# Altium schematic sheet SKD26502-TAH.SchDoc
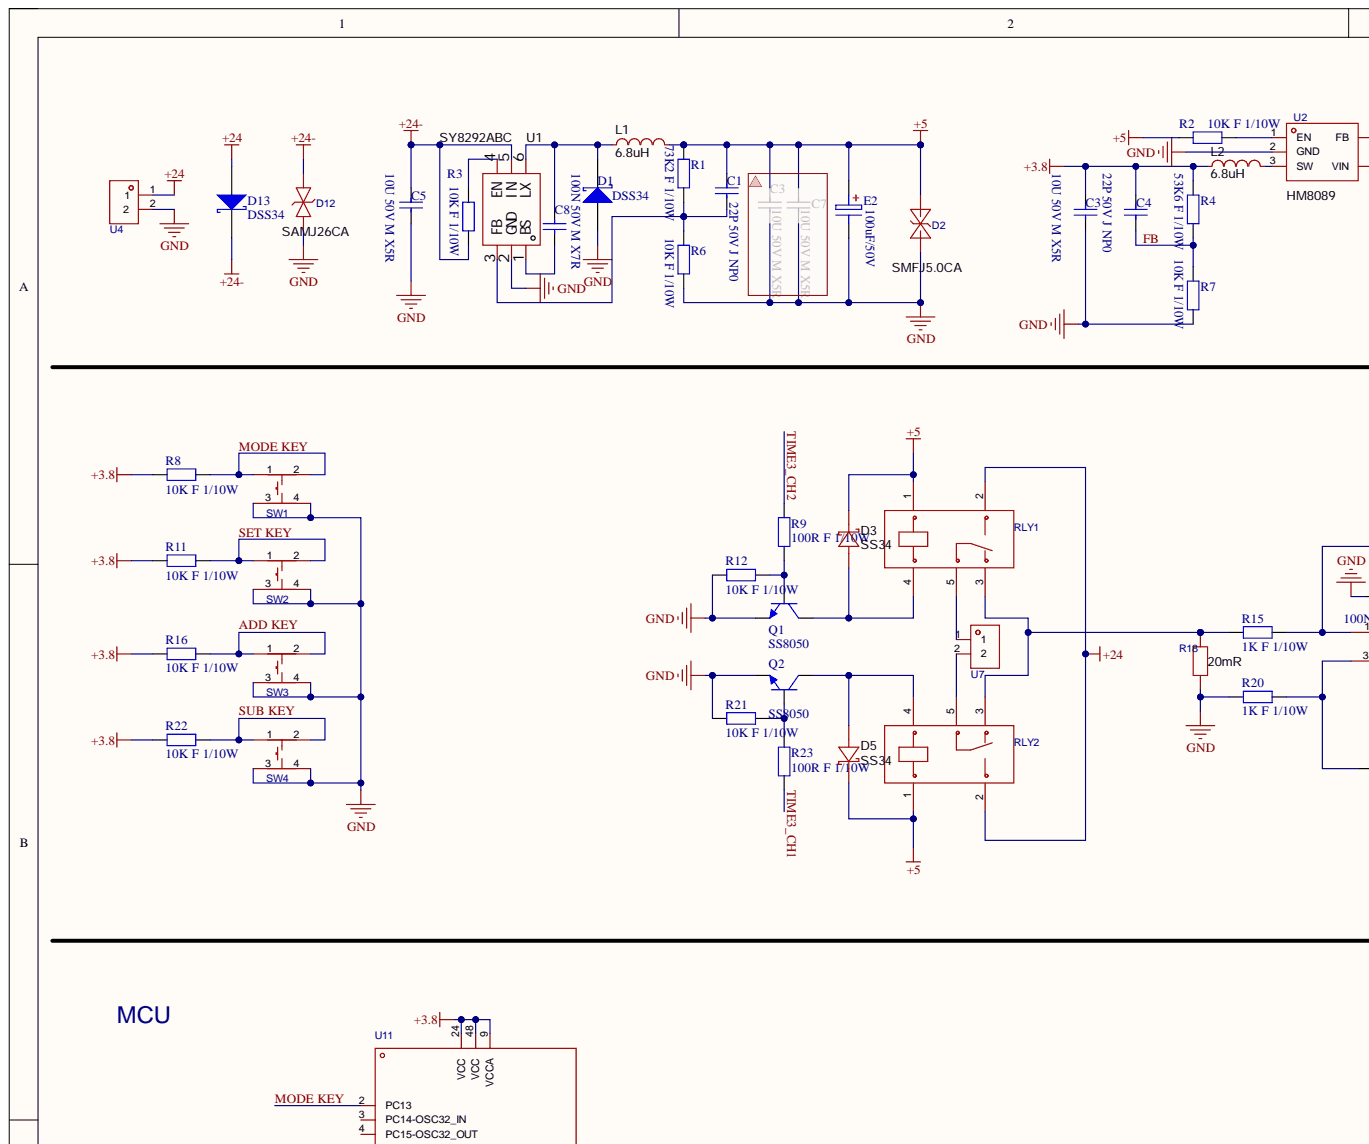
[diagram p0: SKD26502-TAH.SchDoc, top left]
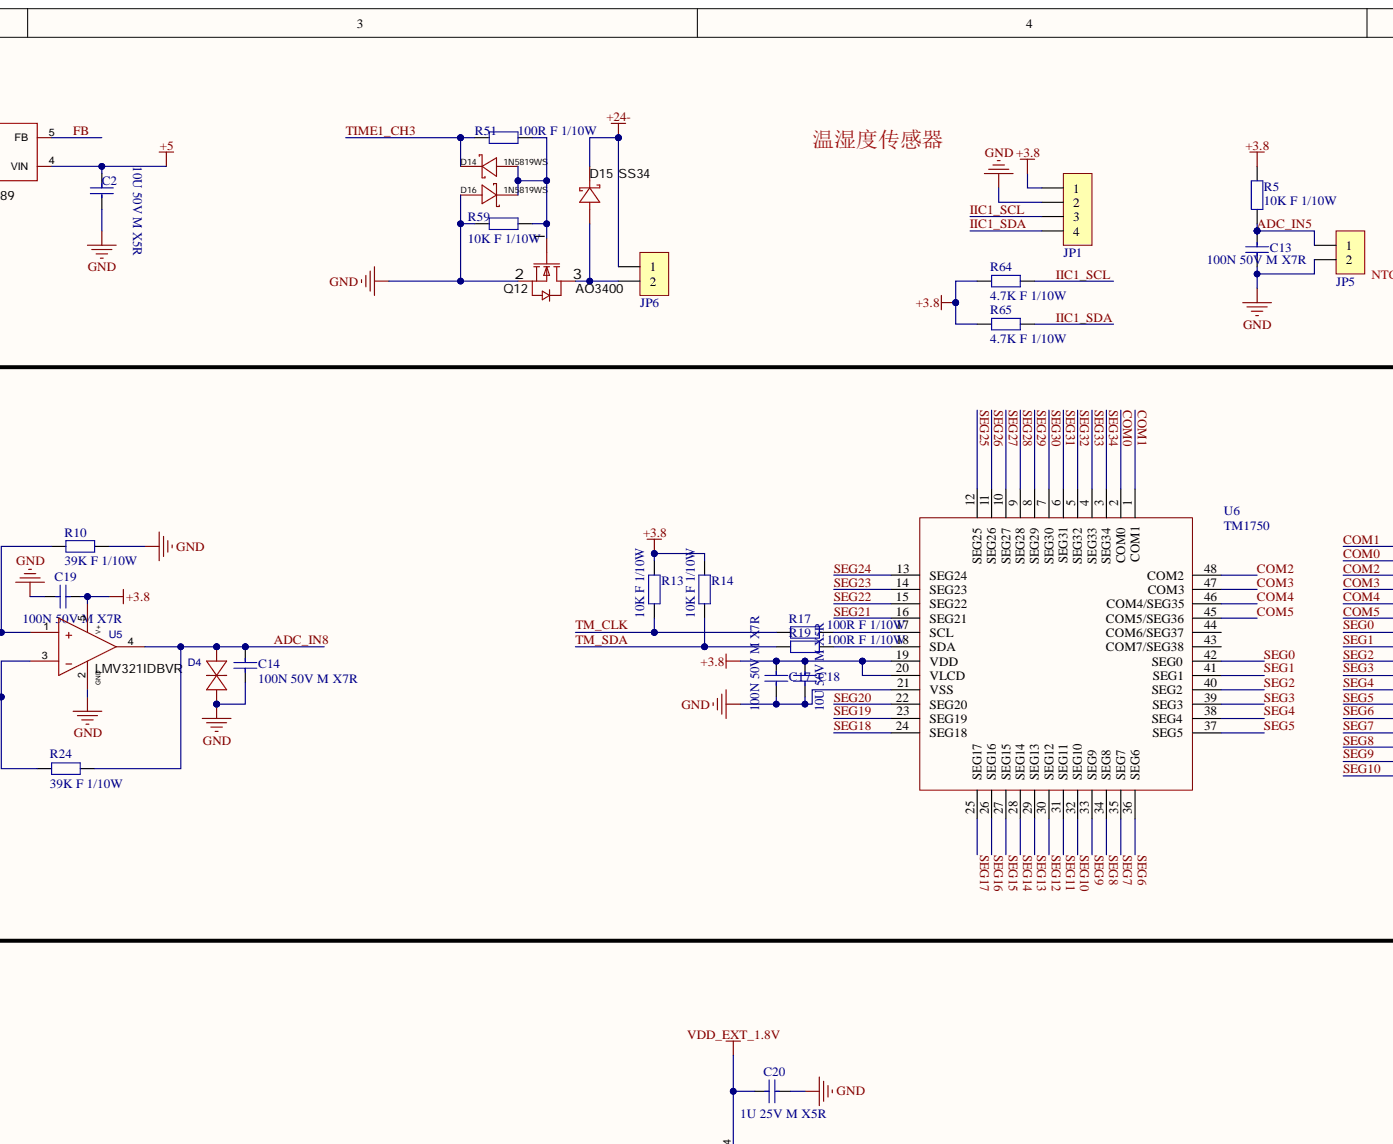
[diagram p1: SKD26502-TAH.SchDoc, top centre]
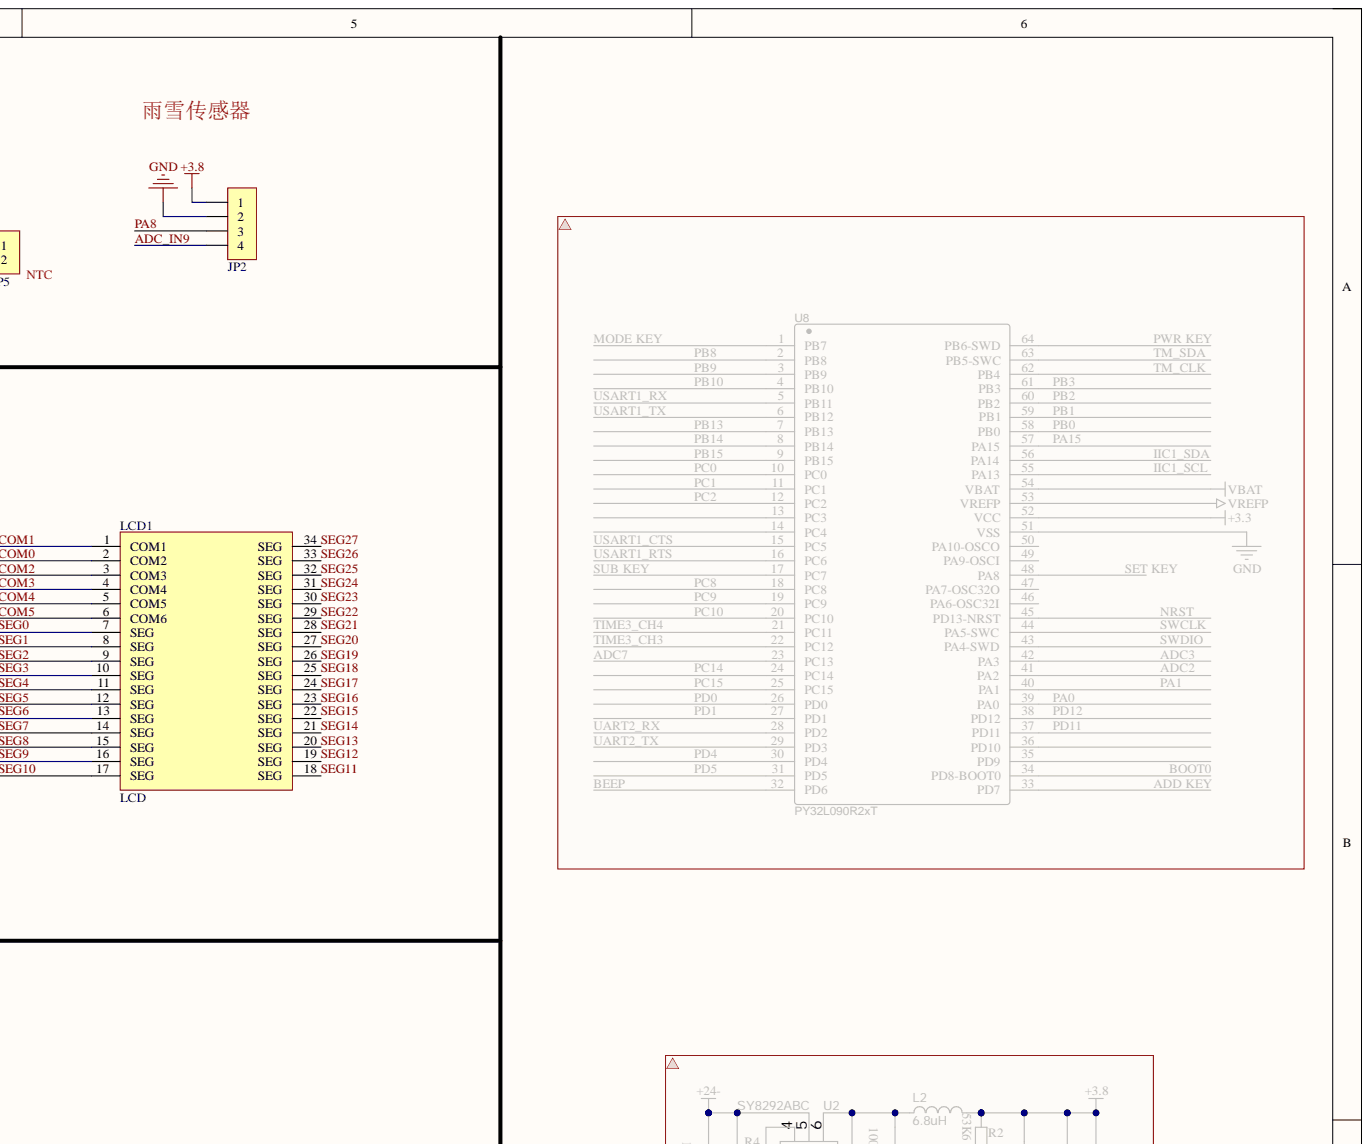
[diagram p2: SKD26502-TAH.SchDoc, top right]
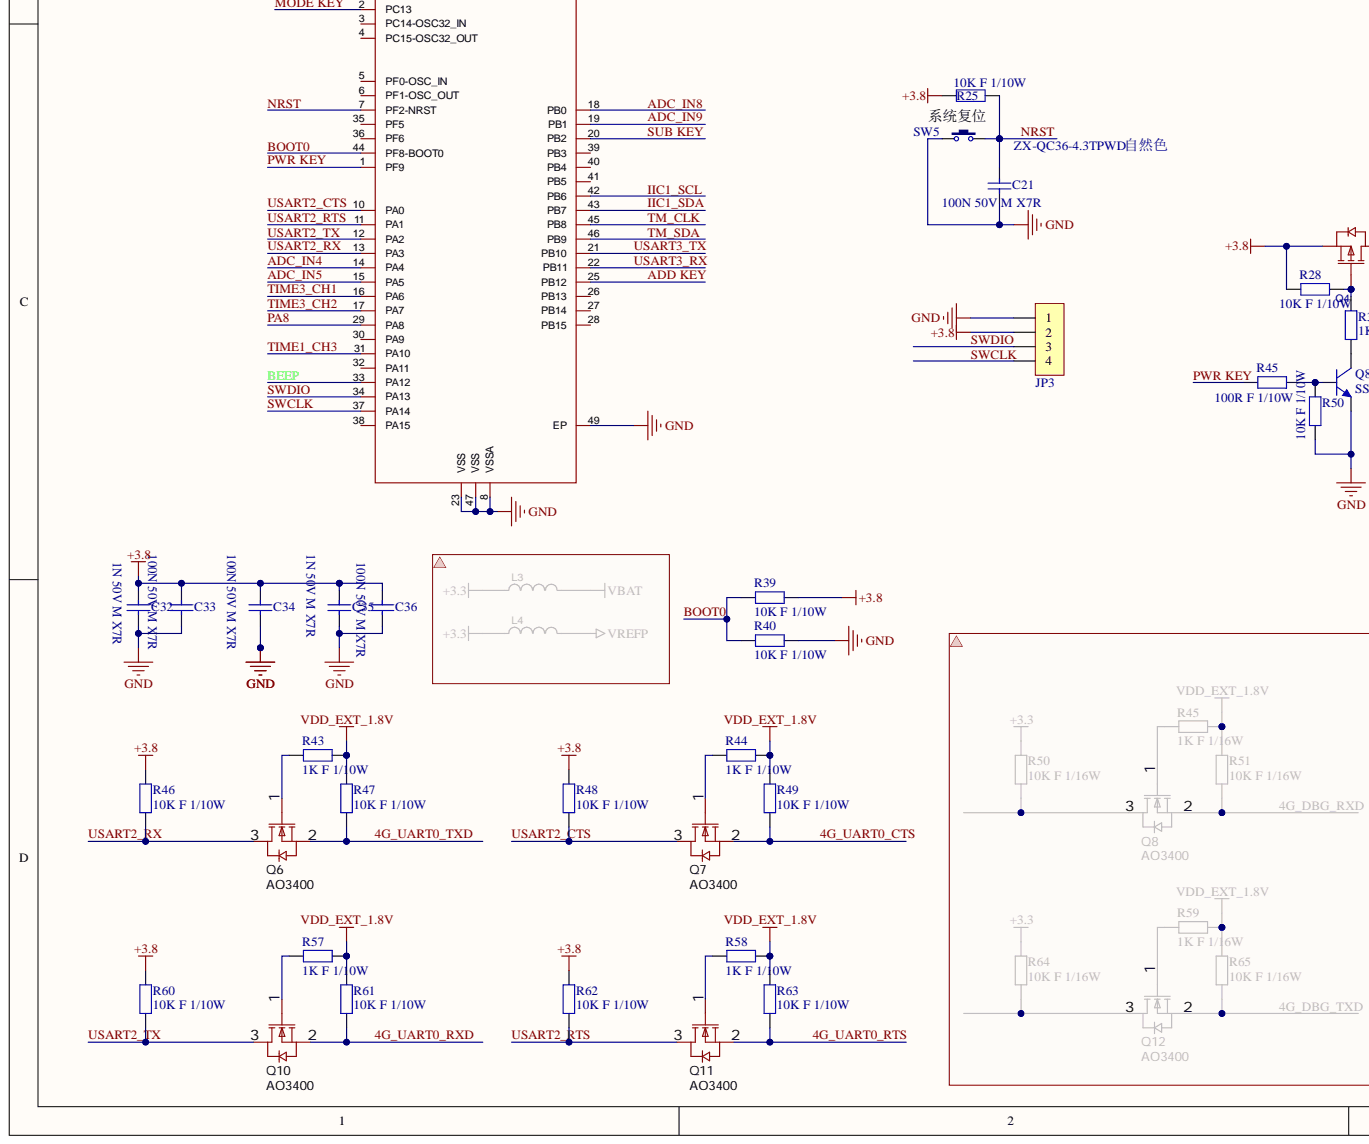
[diagram p3: SKD26502-TAH.SchDoc, bottom left]
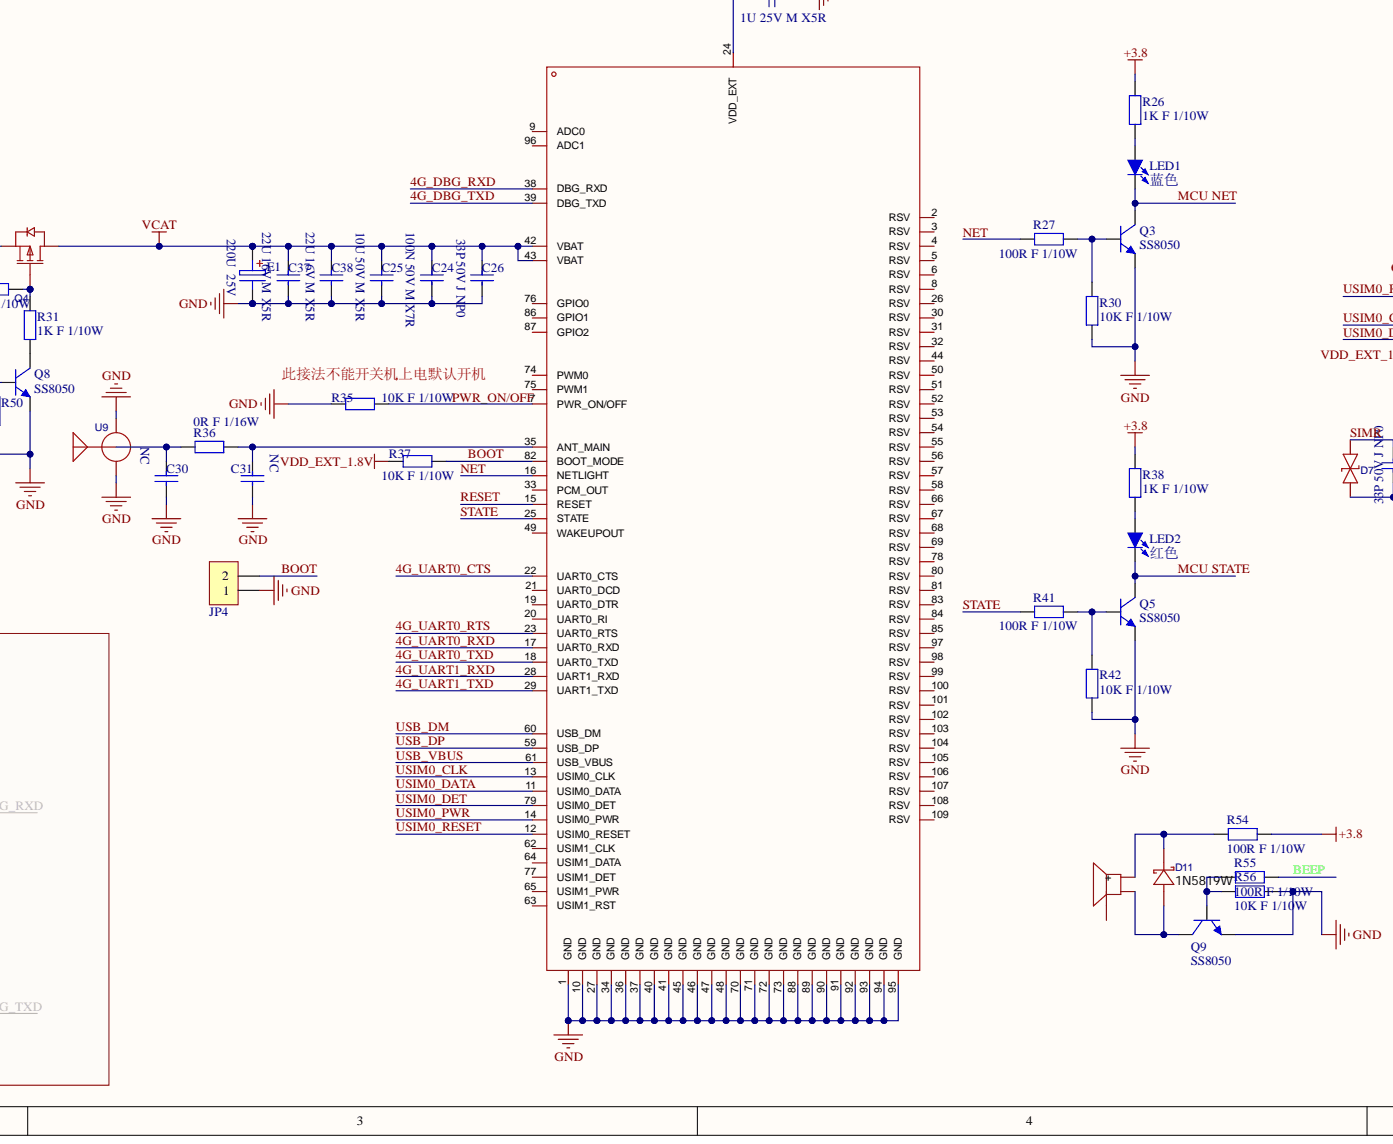
[diagram p4: SKD26502-TAH.SchDoc, bottom centre]
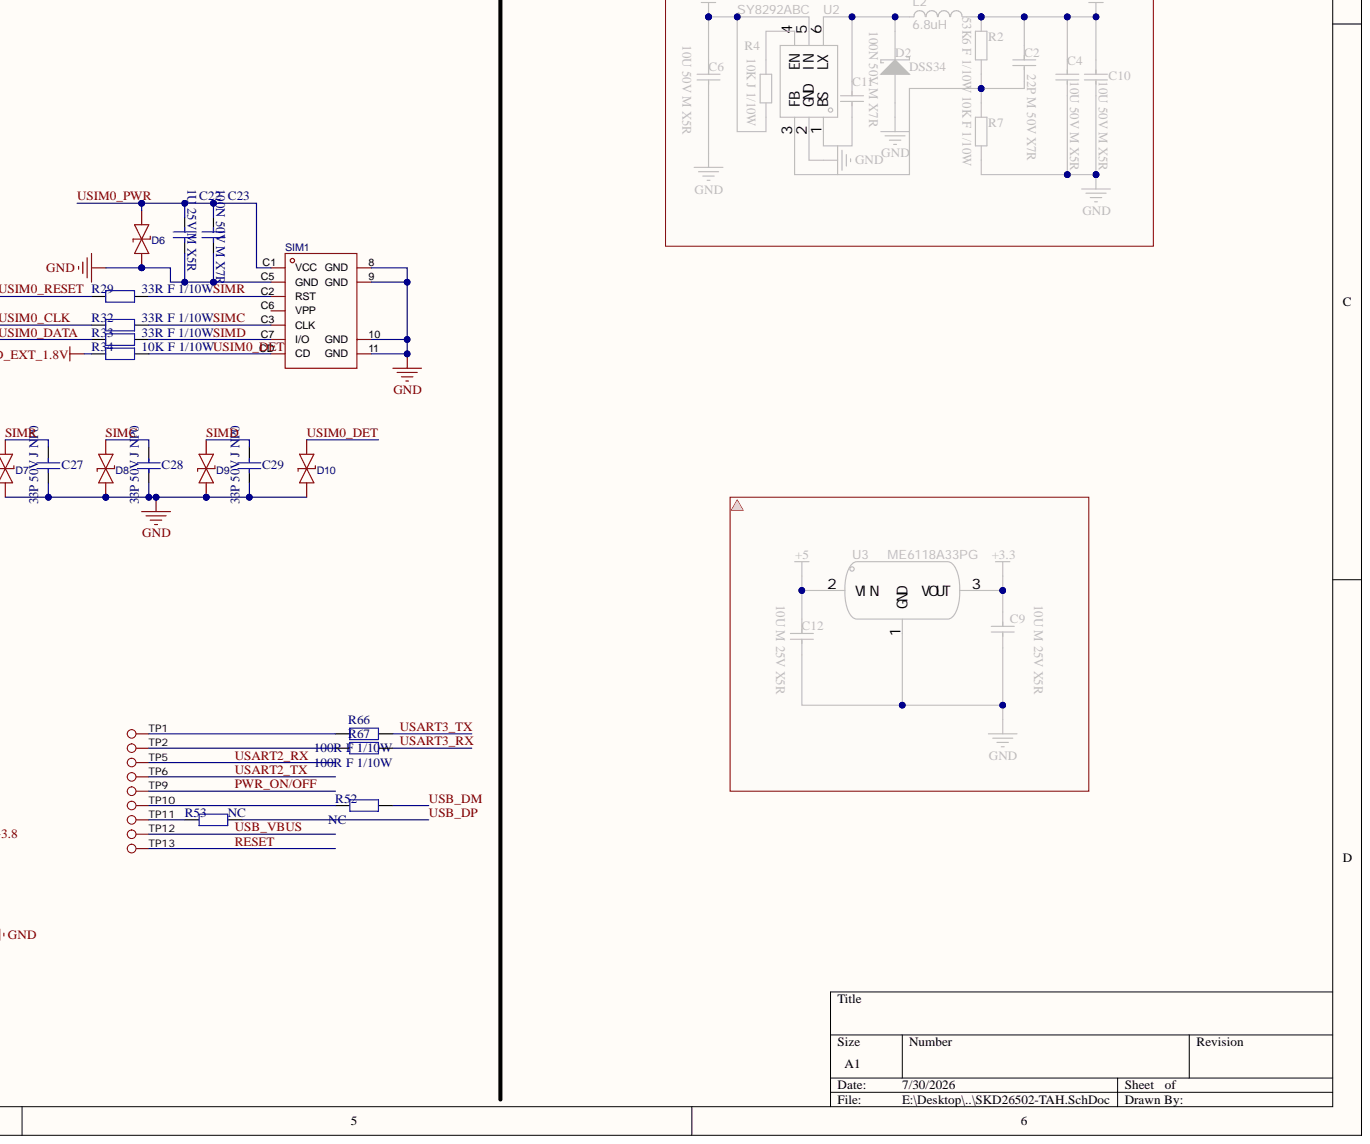
[diagram p5: SKD26502-TAH.SchDoc, bottom right]

## Parts
BUZZER1  smd5020-zk  [BUZ-SMD]  (value not drawn)
C1  22P 50V J NP0  [C 0603_L]
C2  10U 50V M X5R  [C 0603_L]
C3  10U 50V M X5R  [C 0603_L]
C4  22P 50V J NP0  [C 0603_L]
C5  10U 50V M X5R  [C 0603_L]
C8  100N 50V M X7R  [C 0603_L]
C13  100N 50V M X7R  [C 0603_L]
C14  100N 50V M X7R  [C 0603_L]
C17  100N 50V M X7R  [C 0603_L]
C18  10U 50V M X5R  [C 0603_L]
C19  100N 50V M X7R  [C 0603_L]
C20  1U 25V M X5R  [C 0603_L]
C21  100N 50V M X7R  [C 0603_L]
C22  1U 25V M X5R  [C 0603_L]
C23  100N 50V M X7R  [C 0603_L]
C24  100N 50V M X7R  [C 0603_L]
C25  10U 50V M X5R  [C 0603_L]
C26  33P 50V J NP0  [C 0603_L]
C27  33P 50V J NP0  [C 0603_L]
C28  33P 50V J NP0  [C 0603_L]
C29  33P 50V J NP0  [C 0603_L]
C30  NC  [C 0603_L]
C31  NC  [C 0603_L]
C32  1N 50V M X7R  [C 0603_L]
C33  100N 50V M X7R  [C 0603_L]
C34  100N 50V M X7R  [C 0603_L]
C35  1N 50V M X7R  [C 0603_L]
C36  100N 50V M X7R  [C 0603_L]
C37  22U 16V M X5R  [C 0805_L]
C38  22U 16V M X5R  [C 0805_L]
D1  DSS34  [SMA]
D2  SMFJ5.0CA  [SMF]
D3  SS34  [SMA]
D4  SMAJ3.3CA_C19077557  [SMF]  (value not drawn)
D5  SS34  [SMA]
D6  h3v3hd3b  [SOD-323-]  (value not drawn)
D7  h3v3hd3b  [SOD-323-]  (value not drawn)
D8  h3v3hd3b  [SOD-323-]  (value not drawn)
D9  h3v3hd3b  [SOD-323-]  (value not drawn)
D10  h3v3hd3b  [SOD-323-]  (value not drawn)
D11  1N5819W  [SOD-123]
D12  SAMJ26CA  [SMA TVS]
D13  DSS34  [SMA]
D14  1N5819WS  [SOD-323]
D15  SS34  [SMA]
D16  1N5819WS  [SOD-323]
E1  220U  25V  [CM D(6.3*5.4)]
E2  100uF/50V  [CM D(6.3*7.7)]
JP1  HDR-1X4  [PH2.0-LI-4P]  (value not drawn)
JP2  HDR-1X4  [PH2.0-LI-4P]  (value not drawn)
JP3  HDR-1X4  [HDR2.54-LI-4P]  (value not drawn)
JP4  HDR-1X2  [HDR2.54-LI-2P]  (value not drawn)
JP5  HDR-1X2  [PH2.0-LI-2P]  (value not drawn)
JP6  HDR-1X2  [PH2.0-LI-2P]  (value not drawn)
L1  6.8uH  [CD42]
L2  6.8uH  [CD42]
LCD1  LCD  [LCD]
LED1  蓝色  [LED 0603B]
LED2  红色  [LED 0603B]
Q1  SS8050  [SOT23-3N]
Q2  SS8050  [SOT23-3N]
Q3  SS8050  [SOT23-3N]
Q4  ao3401a_c15127  [SOT23-3N]  (value not drawn)
Q5  SS8050  [SOT23-3N]
Q6  AO3400  [SOT23-3N]
Q7  AO3400  [SOT23-3N]
Q8  SS8050  [SOT23-3N]
Q9  SS8050  [SOT23-3N]
Q10  AO3400  [SOT23-3N]
Q11  AO3400  [SOT23-3N]
Q12  AO3400  [SOT23-3N]
R1  73K2 F 1/10W  [R 0603_L]
R2  10K F 1/10W  [R 0603_L]
R3  10K F 1/10W  [R 0603_L]
R4  53K6 F 1/10W  [R 0603_L]
R5  10K F 1/10W  [R 0603_L]
R6  10K F 1/10W  [R 0603_L]
R7  10K F 1/10W  [R 0603_L]
R8  10K F 1/10W  [R 0603_L]
R9  100R F 1/10W  [R 0603_L]
R10  39K F 1/10W  [R 0603_L]
R11  10K F 1/10W  [R 0603_L]
R12  10K F 1/10W  [R 0603_L]
R13  10K F 1/10W  [R 0603_L]
R14  10K F 1/10W  [R 0603_L]
R15  1K F 1/10W  [R 0603_L]
R16  10K F 1/10W  [R 0603_L]
R17  100R F 1/10W  [R 0603_L]
R18  20mR  [R2512]
R19  100R F 1/10W  [R 0603_L]
R20  1K F 1/10W  [R 0603_L]
R21  10K F 1/10W  [R 0603_L]
R22  10K F 1/10W  [R 0603_L]
R23  100R F 1/10W  [R 0603_L]
R24  39K F 1/10W  [R 0603_L]
R25  10K F 1/10W  [R 0603_L]
R26  1K F 1/10W  [R 0603_L]
R27  100R F 1/10W  [R 0603_L]
R28  10K F 1/10W  [R 0603_L]
R29  33R F 1/10W  [R 0603_L]
R30  10K F 1/10W  [R 0603_L]
R31  1K F 1/10W  [R 0603_L]
R32  33R F 1/10W  [R 0603_L]
R33  33R F 1/10W  [R 0603_L]
R34  10K F 1/10W  [R 0603_L]
R35  10K F 1/10W  [R 0603_L]
R36  0R F 1/16W  [R 0603_L]
R37  10K F 1/10W  [R 0603_L]
R38  1K F 1/10W  [R 0603_L]
R39  10K F 1/10W  [R 0603_L]
R40  10K F 1/10W  [R 0603_L]
R41  100R F 1/10W  [R 0603_L]
R42  10K F 1/10W  [R 0603_L]
R43  1K F 1/10W  [R 0603_L]
R44  1K F 1/10W  [R 0603_L]
R45  100R F 1/10W  [R 0603_L]
R46  10K F 1/10W  [R 0603_L]
R47  10K F 1/10W  [R 0603_L]
R48  10K F 1/10W  [R 0603_L]
R49  10K F 1/10W  [R 0603_L]
R50  10K F 1/10W  [R 0603_L]
R51  100R F 1/10W  [R 0603_L]
R52  NC  [R 0402_L]
R53  NC  [R 0402_L]
R54  100R F 1/10W  [R 0603_L]
R55  100R F 1/10W  [R 0603_L]
R56  10K F 1/10W  [R 0603_L]
R57  1K F 1/10W  [R 0603_L]
R58  1K F 1/10W  [R 0603_L]
R59  10K F 1/10W  [R 0603_L]
R60  10K F 1/10W  [R 0603_L]
R61  10K F 1/10W  [R 0603_L]
R62  10K F 1/10W  [R 0603_L]
R63  10K F 1/10W  [R 0603_L]
R64  4.7K F 1/10W  [R 0603_L]
R65  4.7K F 1/10W  [R 0603_L]
R66  100R F 1/10W  [R 0603_L]
R67  100R F 1/10W  [R 0603_L]
RLY1  srd-05vdc-sl-c  [RELAY-TH]  (value not drawn)
RLY2  srd-05vdc-sl-c  [RELAY-TH]  (value not drawn)
SIM1  nano sim 7p h1.37  [SIM_SMD_NANO]  (value not drawn)
SW1  zx-qc66-8.5tp  [SMD_4P]  (value not drawn)
SW2  zx-qc66-8.5tp  [SMD_4P]  (value not drawn)
SW3  zx-qc66-8.5tp  [SMD_4P]  (value not drawn)
SW4  zx-qc66-8.5tp  [SMD_4P]  (value not drawn)
SW5  ZX-QC36-4.3TPWD自然色  [TSW SMD-3*6*2.5]
TP1  (no value)  [1.0TESTPOINT]
TP2  (no value)  [1.0TESTPOINT]
TP5  (no value)  [1.0TESTPOINT]
TP6  (no value)  [1.0TESTPOINT]
TP9  (no value)  [1.0TESTPOINT]
TP10  (no value)  [1.0TESTPOINT]
TP11  (no value)  [1.0TESTPOINT]
TP12  (no value)  [1.0TESTPOINT]
TP13  (no value)  [1.0TESTPOINT]
U1  SY8292ABC  [SOT23-6N]
U2  HM8089  [SOT-23-5-]
U4  kf7620-7.62-2p  [7.62*2P]  (value not drawn)
U5  LMV321IDBVR  [SOT23-5]
U6  TM1750  [LQFP48-7*7]
U7  kf7620-7.62-2p  [7.62*2P]  (value not drawn)
U9  ipex1-txz 142  [ANT-SMD]  (value not drawn)
U10  ml307c-dl  [LCC-LGA-109_L17.7-W15.8-P1.10_MC661]  (value not drawn)
U11  py32f071c1bu6tr  [QFN-48]  (value not drawn)

## Nets
+3.8: C3.2 C4.2 C17.2 C18.2 C19.2 C32.2 C33.2 C34.2 C35.2 C36.2 JP1.1 JP2.1 JP3.2 L2.1 Q4.2 R4.2 R5.2 R8.1 R11.1 R13.2 R14.2 R16.1 R22.1 R25.2 R26.2 R28.1 R38.2 R39.1 R46.2 R48.2 R54.2 R60.2 R62.2 R64.2 R65.2 U5.5 U6.19(VDD) U6.20(VLCD) U11.9 U11.24(VCC) U11.48(VCC)
+5: C1.2 C2.2 D2.2 D3.2 D5.2 E2.1 L1.2 R1.2 R2.2 RLY1.1 RLY2.1 U2.4(VIN)
+24: D13.1 RLY1.2 RLY2.2 U4.1
+24-: C5.2 D12.2 D13.2 D15.2 JP6.1 R3.1 U1.5(IN)
GND: C2.1 C3.1 C5.1 C13.2 C14.2 C17.1 C18.1 C19.1 C20.1 C21.2 C22.1 C23.1 C24.1 C25.1 C26.1 C27.2 C28.2 C29.2 C30.1 C31.1 C32.1 C33.1 C34.1 C35.1 C36.1 C37.1 C38.1 D1.1 D2.1 D4.1 D6.1 D7.1 D8.1 D9.1 D10.1 D12.1 D16.2 E1.2 E2.2 JP1.2 JP2.2 JP3.1 JP4.1 JP5.2 Q1.2 Q2.2 Q3.2 Q5.2 Q8.2 Q9.2 Q12.2 R6.1 R7.1 R10.2 R12.2 R18.1 R20.1 R21.2 R30.2 R35.1 R40.1 R42.2 R50.2 R56.1 R59.2 SIM1.8(GND) SIM1.9(GND) SIM1.10(GND) SIM1.11(GND) SIM1.C5(GND) SW1.3 SW1.4 SW2.3 SW2.4 SW3.3 SW3.4 SW4.3 SW4.4 SW5.1 U1.2(GND) U2.2(GND) U4.2 U5.2 U6.21(VSS) U9.2 U9.3 U10.1(GND) U10.10(GND) U10.27(GND) U10.34(GND) U10.36(GND) U10.37(GND) U10.40(GND) U10.41(GND) U10.45(GND) U10.46(GND) U10.47(GND) U10.48(GND) U10.70(GND) U10.71(GND) U10.72 U10.73 U10.88(GND) U10.89(GND) U10.90(GND) U10.91(GND) U10.92(GND) U10.93(GND) U10.94(GND) U10.95(GND) U11.8 U11.23(VSS) U11.47(VSS) U11.49(EP)
VCAT: C24.2 C25.2 C26.2 C37.2 C38.2 E1.1 Q4.3 U10.42(VBAT) U10.43(VBAT)
VDD_EXT_1.8V: C20.2 R34.1 R37.1 R43.1 R44.1 R47.2 R49.2 R57.1 R58.1 R61.2 R63.2 U10.24(VDD_EXT)
4G_DBG_RXD: U10.38(DBG_RXD)
4G_DBG_TXD: U10.39(DBG_TXD)
4G_UART0_CTS: Q7.2 R49.1 U10.22(UART0_CTS)
4G_UART0_RTS: Q11.2 R63.1 U10.23(UART0_RTS)
4G_UART0_RXD: Q10.2 R61.1 U10.17(UART0_RXD)
4G_UART0_TXD: Q6.2 R47.1 U10.18(UART0_TXD)
4G_UART1_RXD: U10.28(UART1_RXD)
4G_UART1_TXD: U10.29(UART1_TXD)
ADC_IN4: U11.14(PA4)
ADC_IN5: C13.1 JP5.1 R5.1 U11.15(PA5)
ADC_IN8: C14.1 D4.2 R24.2 U5.4 U11.18(PB0)
ADC_IN9: JP2.4 U11.19(PB1)
ADD KEY: R16.2 SW3.1 SW3.2 U11.25(PB12)
BEEP: R55.2 U11.33(PA12)
BOOT: JP4.2 R37.2 U10.82(BOOT_MODE)
BOOT0: R39.2 R40.2 U11.44(PF8-BOOT0)
COM0: LCD1.2(COM2) U6.2(COM0)
COM1: LCD1.1(COM1) U6.1(COM1)
COM2: LCD1.3(COM3) U6.48(COM2)
COM3: LCD1.4(COM4) U6.47(COM3)
COM4: LCD1.5(COM5) U6.46(COM4/SEG35)
COM5: LCD1.6(COM6) U6.45(COM5/SEG36)
FB: C4.1 R4.1 R7.2 U2.5(FB)
IIC1_SCL: JP1.3 R64.1 U11.42(PB6)
IIC1_SDA: JP1.4 R65.1 U11.43(PB7)
MCU NET: LED1.2 Q3.3
MCU STATE: LED2.2 Q5.3
MODE KEY: R8.2 SW1.1 SW1.2 U11.2(PC13)
NET: R27.1 U10.16(NETLIGHT)
NRST: C21.1 R25.1 SW5.2 U11.7(PF2-NRST)
PA8: JP2.3 U11.29(PA8)
PWR KEY: R45.1 U11.1(PF9)
PWR_ON/OFF: R35.2 TP9.1 U10.7(PWR_ON/OFF)
RESET: TP13.1 U10.15(RESET)
SEG0: LCD1.7(SEG) U6.42(SEG0)
SEG1: LCD1.8(SEG) U6.41(SEG1)
SEG2: LCD1.9(SEG) U6.40(SEG2)
SEG3: LCD1.10(SEG) U6.39(SEG3)
SEG4: LCD1.11(SEG) U6.38(SEG4)
SEG5: LCD1.12(SEG) U6.37(SEG5)
SEG6: LCD1.13(SEG) U6.36(SEG6)
SEG7: LCD1.14(SEG) U6.35(SEG7)
SEG8: LCD1.15(SEG) U6.34(SEG8)
SEG9: LCD1.16(SEG) U6.33(SEG9)
SEG10: LCD1.17(SEG) U6.32(SEG10)
SEG11: LCD1.18(SEG) U6.31(SEG11)
SEG12: LCD1.19(SEG) U6.30(SEG12)
SEG13: LCD1.20(SEG) U6.29(SEG13)
SEG14: LCD1.21(SEG) U6.28(SEG14)
SEG15: LCD1.22(SEG) U6.27(SEG15)
SEG16: LCD1.23(SEG) U6.26(SEG16)
SEG17: LCD1.24(SEG) U6.25(SEG17)
SEG18: LCD1.25(SEG) U6.24(SEG18)
SEG19: LCD1.26(SEG) U6.23(SEG19)
SEG20: LCD1.27(SEG) U6.22(SEG20)
SEG21: LCD1.28(SEG) U6.16(SEG21)
SEG22: LCD1.29(SEG) U6.15(SEG22)
SEG23: LCD1.30(SEG) U6.14(SEG23)
SEG24: LCD1.31(SEG) U6.13(SEG24)
SEG25: LCD1.32(SEG) U6.12(SEG25)
SEG26: LCD1.33(SEG) U6.11(SEG26)
SEG27: LCD1.34(SEG) U6.10(SEG27)
SEG28: U6.9(SEG28)
SEG29: U6.8(SEG29)
SEG30: U6.7(SEG30)
SEG31: U6.6(SEG31)
SEG32: U6.5(SEG32)
SEG33: U6.4(SEG33)
SEG34: U6.3(SEG34)
SET KEY: R11.2 SW2.1 SW2.2
SIMC: C28.1 D8.2 R32.2 SIM1.C3(CLK)
SIMD: C29.1 D9.2 R33.2 SIM1.C7(I/O)
SIMR: C27.1 D7.2 R29.2 SIM1.C2(RST)
STATE: R41.1 U10.25(STATE)
SUB KEY: R22.2 SW4.1 SW4.2 U11.20(PB2)
SWCLK: JP3.4 U11.37(PA14)
SWDIO: JP3.3 U11.34(PA13)
TIME1_CH3: D14.1 R51.1 U11.31(PA10)
TIME3_CH1: R23.2 U11.16(PA6)
TIME3_CH2: R9.2 U11.17(PA7)
TM_CLK: R13.1 R17.2 U11.45(PB8)
TM_SDA: R14.1 R19.1 U11.46(PB9)
USART2_CTS: Q7.3 R48.1 U11.10(PA0)
USART2_RTS: Q11.3 R62.1 U11.11(PA1)
USART2_RX: Q6.3 R46.1 TP5.1 U11.13(PA3)
USART2_TX: Q10.3 R60.1 TP6.1 U11.12(PA2)
USART3_RX: R67.2 U11.22(PB11)
USART3_TX: R66.2 U11.21(PB10)
USB_DM: R52.2 U10.60(USB_DM)
USB_DP: R53.2 U10.59(USB_DP)
USB_VBUS: TP12.1 U10.61(USB_VBUS)
USIM0_CLK: R32.1 U10.13(USIM0_CLK)
USIM0_DATA: R33.1 U10.11(USIM0_DATA)
USIM0_DET: D10.2 R34.2 SIM1.CD U10.79(USIM0_DET)
USIM0_PWR: C22.2 C23.2 D6.2 SIM1.C1(VCC) U10.14(USIM0_PWR)
USIM0_RESET: R29.1 U10.12(USIM0_RESET)
NetBUZZER1_1: BUZZER1.1 D11.1 R54.1
NetBUZZER1_2: BUZZER1.2 D11.2 Q9.3
NetC1_1: C1.1 R1.1 R6.2 U1.3(FB)
NetC8_1: C8.1 U1.1(BS)
NetC8_2: C8.2 D1.2 L1.1 U1.6(LX)
NetC30_2: C30.2 R36.1 U9.1
NetC31_2: C31.2 R36.2 U10.35(ANT_MAIN)
NetD3_1: D3.1 Q1.3 RLY1.4
NetD5_1: D5.1 Q2.3 RLY2.4
NetD14_2: D14.2 D16.1 Q12.1 R51.2 R59.1
NetD15_1: D15.1 JP6.2 Q12.3
NetL2_2: L2.2 U2.3(SW)
NetLED1_1: LED1.1 R26.1
NetLED2_1: LED2.1 R38.1
NetQ1_1: Q1.1 R9.1 R12.1
NetQ2_1: Q2.1 R21.1 R23.1
NetQ3_1: Q3.1 R27.2 R30.1
NetQ4_1: Q4.1 R28.2 R31.2
NetQ5_1: Q5.1 R41.2 R42.1
NetQ6_1: Q6.1 R43.2
NetQ7_1: Q7.1 R44.2
NetQ8_1: Q8.1 R45.2 R50.1
NetQ8_3: Q8.3 R31.1
NetQ9_1: Q9.1 R55.1 R56.2
NetQ10_1: Q10.1 R57.2
NetQ11_1: Q11.1 R58.2
NetR2_1: R2.1 U2.1(EN)
NetR3_2: R3.2 U1.4(EN)
NetR10_1: R10.1 R15.2 U5.1
NetR15_1: R15.1 R18.2 RLY1.3 RLY2.3
NetR17_1: R17.1 U6.17(SCL)
NetR19_2: R19.2 U6.18(SDA)
NetR20_2: R20.2 R24.1 U5.3
NetR52_1: R52.1 TP10.1
NetR53_1: R53.1 TP11.1
NetR66_1: R66.1 TP1.1
NetR67_1: R67.1 TP2.1
NetRLY1_5: RLY1.5 U7.1
NetRLY2_5: RLY2.5 U7.2

Not connected: BUZZER1 3; SIM1 C6(VPP); U6 43(COM7/SEG38) 44(COM6/SEG37); U10 2(RSV) 3(RSV) 4(RSV) 5(RSV) 6(RSV) 8(RSV) 9(ADC0) 19(UART0_DTR) 20(UART0_RI) 21(UART0_DCD) 26(RSV) 30(RSV) 31(RSV) 32(RSV) 33(PCM_OUT) 44(RSV) 49(WAKEUPOUT) 50(RSV) 51(RSV) 52(RSV) 53(RSV) 54(RSV) 55(RSV) 56(RSV) 57(RSV) 58(RSV) 62(USIM1_CLK) 63(USIM1_RST) 64(USIM1_DATA) 65(USIM1_PWR) 66(RSV) 67(RSV) 68(RSV) 69(RSV) 74(PWM0) 75(PWM1) 76(GPIO0) 77(USIM1_DET) 78(RSV) 80(RSV) 81(RSV) 83(RSV) 84(RSV) 85(RSV) 86(GPIO1) 87(GPIO2) 96(ADC1) 97(RSV) 98(RSV) 99(RSV) 100(RSV) 101(RSV) 102(RSV) 103(RSV) 104(RSV) 105(RSV) 106(RSV) 107(RSV) 108(RSV) 109(RSV); U11 3(PC14-OSC32_IN) 4(PC15-OSC32_OUT) 5(PF0-OSC_IN) 6(PF1-OSC_OUT) 26(PB13) 27(PB14) 28(PB15) 30(PA9) 32(PA11) 35(PF5) 36(PF6) 38(PA15) 39(PB3) 40(PB4) 41(PB5)

## Library data of the parts
BUZZER1 = smd5020-zk: 驱动方式:无源(外部驱动);构造类型:电磁式;额定电压:3V;频率:4kHz;声压(SPL):78dB;; Manufacturer: XHXDZ(兴华鑫); AddInBom: yes; Current Consumption: 100mA; Sound Pressure Level(SPL): 78dB; Value: 4kHz; Voltage - Supply: 2V~4V; Voltage Rating: 3V; Component Kind: Standard; ConvertToPcb: yes; Datasheet: https://item.szlcsc.com/datasheet/SMD5020-ZK/51674924.html; Driver Circuitry: 无源(外部驱动); FootprintName: BUZ-SMD_3P-SMD5020-ZK; Height: 2mm; JLCPCB Part Class: Extended Part; LCSC Part Name: 蜂鸣器; Length: 5mm; ManufacturerPart: SMD5020-ZK; Supplier: LCSC; Supplier Footprint: SMD,5.3x5.3mm; SupplierPart: C49246955; Symbol: SMD5020-ZK; Technology: 电磁式; Width: 5mm
C1-C5 C8 C13 C14 C17-C38 = C: 无极性贴片电容; 版权归属: 源创客; BOM名称: 无极性贴片电容; Component Kind: Standard; Library Name: 0.1 - 电阻-电容-电感.SchLib; 学习资源: www.ooocke.com
D1 D13 = SS14: 肖特基二极管; 属性: 40V/1A; BOM名称: 肖特基二极管; Component Kind: Standard; Library Name: 0.2 -  二极管-整流桥.SchLib
D2 = smaj5.0ca_c19077524: 极性:双向;反向截止电压(Vrwm):5V;钳位电压:9.2V;峰值脉冲电流(Ipp):43.5A@10/1000us;峰值脉冲功率(Ppp):400W@10/1000us;击穿电压:7V;; Manufacturer: R+O(宏嘉诚); AddInBom: yes; Clamping Voltage: 9.2V; level of protection: IEC 61000-4-2; ModelId: 528a94b6593f4af79cbff3f4c52e0784; ModelName: SMA_L4.3-W2.6-LS5.0-BI; ModelTransform: 198.4248,102.362,0,0,0,0,0,0,0; Number of Channels: 单路; Polarity: 双向; Reverse Leakage Current (Ir): 800uA; Reverse Stand-Off Voltage (Vrwm): 5V; Type: TVS; Voltage - Breakdown: 7V; Component Kind: Standard; ConvertToPcb: yes; Datasheet: https://item.szlcsc.com/datasheet/SMAJ5.0CA/20275609.html; FootprintName: SMA_L4.3-W2.6-LS5.0-BI; JLCPCB Part Class: Extended Part; LCSC Part Name: 双向TVS 5V截止 峰值浪涌电流：43.5A@10/1000us; ManufacturerPart: SMAJ5.0CA; Operating Temperature: -55℃~+150℃; Peak Pulse Current (Ipp): 43.5A@10/1000us; Peak Pulse Power Dissipation (Ppp): 400W@10/1000us; Supplier: LCSC; Supplier Footprint: DO-214AC(SMA); SupplierPart: C19077524; Symbol: SMAJ5.0CA_C19077524
D3 D5 D15 = SS34_C7420365: Manufacturer: 宏迦橙; Add into BOM: yes; Average Rectified Current (Io): 3A; Diode Configuration: 独立式; Forward Voltage (Vf@If): 550mV@3.0A; Peak forward surge current: 80A; Reverse Leakage Current (Ir): 200uA@40V; Reverse Voltage (Vr): 40V; Component Kind: Standard; Convert to PCB: yes; Datasheet: https://atta.szlcsc.com/upload/public/pdf/source/20230828/75438452AEE6C276EDA92B2AF599BE05.pdf; Device: SS34_C7420365; JLCPCB Part Class: Extended Part; LCSC Part Name: 电压:40V 电流:3A; Manufacturer Part: SS34; PCB3D: SMA_L4.3-W2.6-LS5.0-FD; Supplier: LCSC; Supplier Footprint: SMA(DO-214AC); Supplier Part: C7420365; Symbol: SS34_C7420365
D4 = SMBJ3.3CA_C19077557: Component Kind: Standard; Symbol: SMBJ3.3CA_C19077557
D6-D10 = h3v3hd3b: 极性:双向;反向截止电压(Vrwm):3.3V;钳位电压:17V;峰值脉冲电流(Ipp):25A@8/20us;峰值脉冲功率(Ppp):375W@8/20us;击穿电压:8V;; Manufacturer: R+O(宏嘉诚); AddInBom: yes; Clamping Voltage: 17V; Junction Capacitance: 100pF; level of protection: IEC 61000-4-5；IEC 61000-4-2; ModelId: dd567d373db645b3a267ff184369a271; ModelName: SOD-323_L1.7-W1.3-LS2.7-BI; ModelTransform: 102.362,50.197,0,0,0,0,0,0,0; Polarity: 双向; Reverse Leakage Current (Ir): 500nA; Reverse Stand-Off Voltage (Vrwm): 3.3V; Type: ESD; Voltage - Breakdown: 8V; Component Kind: Standard; ConvertToPcb: yes; Datasheet: https://item.szlcsc.com/datasheet/H3V3HD3B/21992798.html; FootprintName: SOD-323_L1.7-W1.3-LS2.7-BI; JLCPCB Part Class: Extended Part; LCSC Part Name: 丝印:03B 双向ESD 3.3V截止 峰值浪涌电流：25A@8/20us; ManufacturerPart: H3V3HD3B; Number of Channels: -; Operating Temperature: -55℃~+155℃; Peak Pulse Current (Ipp): 25A@8/20us; Peak Pulse Power Dissipation (Ppp): 375W@8/20us; Supplier: LCSC; Supplier Footprint: SOD-323; SupplierPart: C20617915; Symbol: H3V3HD3B
D11 = 1N5819W_C963381: Component Kind: Standard; Symbol: 1N5819W_C963381
D12 = smaj26ca_c19077544: 极性:双向;反向截止电压(Vrwm):26V;钳位电压:42.1V;峰值脉冲电流(Ipp):9.5A@10/1000us;峰值脉冲功率(Ppp):400W@10/1000us;击穿电压:31.9V;; Manufacturer: R+O(宏嘉诚); AddInBom: yes; Clamping Voltage: 42.1V; level of protection: IEC 61000-4-2; ModelId: 528a94b6593f4af79cbff3f4c52e0784; ModelName: SMA_L4.3-W2.6-LS5.0-BI; ModelTransform: 198.4248,102.362,0,0,0,0,0,0,0; Number of Channels: 单路; Polarity: 双向; Reverse Leakage Current (Ir): 1uA; Reverse Stand-Off Voltage (Vrwm): 26V; Type: TVS; Voltage - Breakdown: 31.9V; Component Kind: Standard; ConvertToPcb: yes; Datasheet: https://item.szlcsc.com/datasheet/SMAJ26CA/20275629.html; FootprintName: SMA_L4.3-W2.6-LS5.0-BI; JLCPCB Part Class: Extended Part; LCSC Part Name: 双向TVS 26V截止 峰值浪涌电流：9.5A@10/1000us; ManufacturerPart: SMAJ26CA; Operating Temperature: -; Peak Pulse Current (Ipp): 9.5A@10/1000us; Peak Pulse Power Dissipation (Ppp): 400W@10/1000us; Supplier: LCSC; Supplier Footprint: DO-214AC(SMA); SupplierPart: C19077544; Symbol: SMAJ26CA_C19077544
D14 D16 = 1N5819WS_C191023: Manufacturer: Hottech(合科泰); Add into BOM: yes; Forward Voltage (Vf@If): 600mV@1A; Rectified Current: 1A; Reverse Voltage (Vr): 40V; Component Kind: Standard; Convert to PCB: yes; Datasheet: https://atta.szlcsc.com/upload/public/pdf/source/20220428/3CB86FD8D28D653594B4E35F9D5AEFD9.pdf; Device: 1N5819WS_C191023; JLCPCB Part Class: Basic Part; LCSC Part Name: 电压:40V 电流:1A; Manufacturer Part: 1N5819WS; PCB3D: SOD-323_L1.8-W1.3-LS2.5-RD; Supplier: LCSC; Supplier Footprint: SOD-323; Supplier Part: C191023; Symbol: 1N5819WS
E1 E2 = C-CM: 贴片电解电容; 版权归属: 源创客; BOM名称: 贴片电解电容; Component Kind: Standard; Library Name: 0.1 - 电阻-电容-电感.SchLib; 学习资源: www.ooocke.com
JP1-JP3 = Header 4: 4P接插件; BOM名称: 4P接插件; Component Kind: Standard
  JP1 JP2: Library Name: 5.2 - 接插件-脚距2.0.SchLib
  JP3: Library Name: 5.3 - 接插件-脚距2.54 (100mil) .SchLib
JP4-JP6 = Header 2: 2P接插件; BOM名称: 2P接插件; Component Kind: Standard
  JP4: Library Name: 5.3 - 接插件-脚距2.54 (100mil) .SchLib
  JP5 JP6: Library Name: 5.2 - 接插件-脚距2.0.SchLib
L1 L2 = FHD4020S-6R8MT: Manufacturer: cjiang(长江微电); Add into BOM: yes; Current - Saturation(Isat): 4.2A; Current Rating: 2.8A; DC Resistance(DCR): 130mΩ; Tolerance: ±20%; Type: 绕线电感; Component Kind: Standard; Convert to PCB: yes; Datasheet: https://atta.szlcsc.com/upload/public/pdf/source/20200609/C601990_BCEA243EC4DA0A9A288AA78A6DFB48A5.pdf; Device: FHD4020S-6R8MT; JLCPCB Part Class: Extended Part; LCSC Part Name: 6.8uH ±20% 4.2A; Manufacturer Part: FHD4020S-6R8MT; PCB3D: IND-SMD_L4.0-W4.0-H1.9; Supplier: LCSC; Supplier Footprint: SMD,4x4x2mm; Supplier Part: C843301; Symbol: FHD4020S-6R8MT; Value: 6.8uH
LED1 LED2 = LED-SMD: 贴片LED; BOM名称: 贴片LED; Component Kind: Standard; Library Name: 0.3 - LED发光二极管.SchLib
Q1-Q3 Q5 Q8 Q9 = 8050-SMD: 高频放大-NPN型; 属性: 40V 1.5A 1W 100MHZ; BOM名称: 高频放大-NPN型; Component Kind: Standard; Library Name: 0.4 - 三极管.SchLib
Q4 = ao3401a_c15127: 数量:1个P沟道;漏源电压(Vdss):30V;连续漏极电流(Id):4A;导通电阻(RDS(on)):47mΩ@10V；85mΩ@2.5V；60mΩ@4.5V;耗散功率(Pd):-;阈值电压(Vgs(th)):900mV;; Manufacturer: AOS; AddInBom: yes; Current - Continuous Drain(Id): 4A; Drain to Source Voltage: 30V; Gate Charge(Qg): 14nC@10V; Gate Threshold Voltage (Vgs(th)): 900mV; Input Capacitance(Ciss): 645pF; ModelId: c62791f0466c4ae2a892c056b845b0ca; ModelName: SOT-23-3P_L2.9-W1.3-H1.0-LS2.4-P0.95; ModelTransform: 98.64468,114.173,0,180,0,0,0.001,-0.002,0; Number: 1个P沟道; Output Capacitance(Coss): 80pF; Reverse Transfer Capacitance (Crss@Vds): 55pF; Component Kind: Standard; ConvertToPcb: yes; Datasheet: https://item.szlcsc.com/datasheet/AO3401A/15801.html; FootprintName: SOT-23_L2.9-W1.3-P1.90-LS2.4-BR; JLCPCB Part Class: Basic Part; LCSC Part Name: 1个P沟道 耐压:30V 电流:4A; ManufacturerPart: AO3401A; Operating Temperature: -; Pd - Power Dissipation: -; RDS(on): 47mΩ@10V；85mΩ@2.5V；60mΩ@4.5V; Supplier: LCSC; Supplier Footprint: SOT-23; SupplierPart: C15127; Symbol: AO3401A; Type: -
Q6 Q7 Q10-Q12 = AO3400_C2938367: Manufacturer: GOODWORK(固得沃克); Add into BOM: yes; Current - Continuous Drain(Id): 5.8A; Drain to Source Voltage: 30V; Gate Threshold Voltage (Vgs(th)@Id): 700mV; Input Capacitance(Ciss@Vds): 1.05nF; Pd - Power Dissipation: 1.5W; RDS(on): 52mΩ@2.5V,4A; Reverse Transfer Capacitance (Crss@Vds): 77pF; Type: 1个N沟道; Component Kind: Standard; Convert to PCB: yes; Datasheet: https://atta.szlcsc.com/upload/public/pdf/source/20240805/AF56525E67E43DBB802F95DDDF058BE9.pdf; Device: AO3400_C2938367; Gate Charge(Qg): -; JLCPCB Part Class: Extended Part; LCSC Part Name: 1个N沟道 耐压:30V 电流:5.8A; Manufacturer Part: AO3400; Operating Temperature: -55℃~+150℃; PCB3D: SOT-23-3P_L2.9-W1.3-H1.0-LS2.4-P0.95; Supplier: LCSC; Supplier Footprint: SOT-23; Supplier Part: C2938367; Symbol: AO3400_C2938367
R1-R9 R11-R14 R16 R17 R19 R21-R23 R26-R67 = R: 贴片电阻; 版权归属: 源创客; BOM名称: 贴片电阻; Component Kind: Standard; Library Name: 0.1 - 电阻-电容-电感.SchLib; 学习资源: www.ooocke.com
R10 R15 R20 R24 R25 = R: 贴片电阻; BOM名称: 贴片电阻; Component Kind: Standard; Library Name: 0.1 - 电阻-电容-电感.SchLib
R18 = 352210MJT: Component Kind: Standard; Symbol: 352210MJT
RLY1 RLY2 = srd-05vdc-sl-c: 线圈电压:5V;触点形式:一组转换:1C(单刀双掷-转换);触点容量(电阻负载):-;最大切换电压:250V@AC;最大切换电流:15A;; Manufacturer: 松乐; AddInBom: yes; Coil Rated Power: 360mW; Coil Resistance: 70Ω; Coil Type: 无极性-单线圈; Coil Voltage: 5V; Contact Resistance: 100mΩ; ModelId: d0eda9b8bf8746d18ae810ab88dc78e7; ModelName: RELAY-TH_L19.2-W15.6-H15.8; ModelTransform: 755.904,622.4397,0,0,0,0,34.882,0,-118.11; Operate Time: 10ms; Switching Current(Max): 15A; Component Kind: Standard; Contact Form: 一组转换:1C(单刀双掷-转换); Contact Rating: -; ConvertToPcb: yes; Datasheet: https://item.szlcsc.com/datasheet/SRD-05VDC-SL-C/36422.html; FootprintName: RELAY-TH_SRD-XXVDC-XL-C; JLCPCB Part Class: Extended Part; LCSC Part Name: 蓝色 单组转换型继电器; Length: 19.2mm; ManufacturerPart: SRD-05VDC-SL-C; Number of Pins: 5; Release Time: 10ms; Supplier: LCSC; Supplier Footprint: 插件,19.2x15.6mm; SupplierPart: C35449; Switching Voltage(Max): 250V@AC; Symbol: SRD-05VDC-SL-C; Width: 15.6mm
SIM1 = nano sim 7p h1.37: 卡连接方式:自弹式;卡类型:NanoSIM卡;连接器类型:卡座;本体最大高度:1.37mm;工作温度:-40℃~+75℃;; Manufacturer: SHOU HAN(首韩); AddInBom: yes; Card Connection Mode: 自弹式; Card Detection: 有插卡检测; Connector Type: 卡座; ModelId: eba0bb7c76444586806c60b79b6788b5; ModelName: SIM-SMD_NANO-SIM-7P-H1.37; ModelTransform: 513.3848,573.6209,0,0,0,0,0.002,-10.471,-15.748; Card Type: NanoSIM卡; Component Kind: Standard; ConvertToPcb: yes; Datasheet: https://item.szlcsc.com/datasheet/NANO%2520SIM%25207P%2520H1.37/8629771.html; FootprintName: SIM-SMD_NANO-SIM-7P-H1.37; Height Above Board: 1.37mm; JLCPCB Part Class: Extended Part; LCSC Part Name: 自弹式 NanoSIM卡 卡座; ManufacturerPart: NANO SIM 7P H1.37; Operating Temperature: -40℃~+75℃; Rated Voltage (Max): -; Supplementary Features: -; Supplier: LCSC; Supplier Footprint: SMD; SupplierPart: C7529384; Symbol: NANO SIM 7P H1.37
SW1-SW4 = zx-qc66-8.5tp: 电路结构:单刀单掷;按钮形状:圆形按钮;作用力:2.6N;安装方式:立贴;开关长度:6mm;开关宽度:6mm;开关高度:8.5mm;带支架:不带支架;触点电流:50mA;额定电压:12V;工作寿命:10万次;; Manufacturer: Megastar(兆星); Actuator Style: 圆形按钮; Actuator/Cap Color: 黑色; AddInBom: yes; Circuit: 单刀单掷; Contact Current: 50mA; Life: 10万次; Operating Force: 2.6N; Switch Height: 8.5mm; Voltage Rating: 12V; with Bracket: 不带支架; Component Kind: Standard; ConvertToPcb: yes; Datasheet: https://item.szlcsc.com/datasheet/ZX-QC66-8.5TP/8519165.html; FootprintName: KEY-SMD_4P-L6.0-W6.0-P4.50-LS8.6_H8.5; JLCPCB Part Class: Extended Part; LCSC Part Name: 6*6*8.5贴片; Length: 6mm; ManufacturerPart: ZX-QC66-8.5TP; Mounting Type: 立贴; Operating Temperature: -30℃~+80℃; Supplier: LCSC; Supplier Footprint: SMD-4P,6x6mm; SupplierPart: C7470159; Symbol: ZX-QC66-8.5TP; Termination Style: 鸥翼型; Width: 6mm
SW5 = TSW 3x6: 3x6轻触开关; BOM名称: 3x6轻触开关; Component Kind: Standard; Library Name: 0.7 - 常用按键-开关.SchLib
TP1 TP2 TP5 TP6 TP9-TP13 = 测试点: Component Kind: Standard
U1 = SY8292ABC_C83336: Manufacturer: silergy(矽力杰); Add into BOM: yes; Frequency - Switching: 800kHz; Function: 降压型; Number of Outputs: 1; Output Current: 2A; Output Voltage: 5V; Quiescent Current: 160uA; Switch tube (built-in/external): 内置; Synchronous Rectifier: 否; Topology: 降压式; Voltage - Supply: 5V~40V; Component Kind: Standard; Convert to PCB: yes; Datasheet: https://atta.szlcsc.com/upload/public/pdf/source/20240509/9C841F54295803539CDB8AB62E138127.pdf; Device: SY8292ABC_C83336; JLCPCB Part Class: Extended Part; LCSC Part Name: 高效800kHz 40V输入2A异步降压调节器; Manufacturer Part: SY8292ABC; Operating Temperature: -; Output Type: -; PCB3D: SOT-23-6_L2.9-W1.6-H1.5-LS2.8-P0.95; Supplier: LCSC; Supplier Footprint: SOT-23-6; Supplier Part: C83336; Symbol: SY8292ABC_C83336
U2 = hm8089: 功能类型:降压型;工作电压:2.5V~5.5V;输出电压:600mV~5.5V;输出电流:2.5A;输出类型:可调;; Manufacturer: GATEMODE(捷茂微); AddInBom: yes; Frequency - Switching: 1.2MHz; Function: 降压型; ModelId: b5108020abe84f7bb0f3c398b43b6e0b; ModelName: TSOT-23-5_L2.9-W1.6-H1.00-LS2.8-P0.95; ModelTransform: 110.45568,114.173,0,180,0,0,0,0,0; Number of Outputs: 1; Output Current: 2.5A; Output Type: 可调; Quiescent Current: 60uA; Switch tube (built-in/external): 内置; Synchronous Rectifier: 是; Topology: 降压式; Component Kind: Standard; ConvertToPcb: yes; Datasheet: https://item.szlcsc.com/datasheet/HM8089/2810017.html; Features: 轻载高效模式；逐波限流；过流保护；打嗝限流; FootprintName: TSOT-23-5_L2.9-W1.6-P0.95-LS2.8-BR; JLCPCB Part Class: Extended Part; LCSC Part Name: 降压型 2.5A 2.5V~5.5V; ManufacturerPart: HM8089; Operating Temperature: -40℃~+85℃; Output Voltage: 600mV~5.5V; Supplier: LCSC; Supplier Footprint: SOT-23-5; SupplierPart: C2693745; Symbol: HM8089; Voltage - Supply: 2.5V~5.5V
U4 U7 = kf7620-7.62-2p: 结构:1x2P;间距:7.62mm;颜色:绿色;安装方式:直插;排数:1;每排PIN数:2;额定电流:32A;额定电压:450V;线规 - AWG:10~22;线规 - 平方毫米:4;工作温度:-40℃~+105℃;; Manufacturer: KEFA(科发); AddInBom: yes; Current Rating: 32A; ModelId: 85a71d8b8c5443e2aaf0f82fc3111de8; ModelName: CONN-TH_P7.62_KF7620-7.62-2P; ModelTransform: 615.7468,505.9045,0,0,0,0,-7.874,-40.354,-196.85; Number of PINs Per Row: 2; Number of Positions or Pins: 1x2P; Pitch: 7.62mm; Voltage Rating (Max): 450V; Wire Gauge - AWG: 10~22; Wire Gauge - mm2: 4; Color: 绿色; Component Kind: Standard; ConvertToPcb: yes; Datasheet: https://item.szlcsc.com/datasheet/KF7620-7.62-2P/481600.html; FootprintName: CONN-TH_P7.62_KF7620-7.62-2P; JLCPCB Part Class: Extended Part; LCSC Part Name: 1x2P 7.62mm 直插 排数:1 每排P数:2; ManufacturerPart: KF7620-7.62-2P; Mounting Type: 直插; Number of Pins: 2P; Number of Rows: 1; Operating Temperature: -40℃~+105℃; Supplier: LCSC; Supplier Footprint: 插件,P=7.62mm; SupplierPart: C475104; Symbol: KF7620-7.62-2P
U5 = lmv321idbvr_c7972: 放大器数:1;轨到轨:轨到轨输出;增益带宽积(GBW):1MHz;输入失调电压(Vos):7mV;压摆率(SR):1V/us;输入偏置电流(Ib):11nA;; Manufacturer: TI(德州仪器); AddInBom: yes; Common Mode Rejection Ratio(CMRR): 63dB; Gain Bandwidth Product: 1MHz; Ib - Input Bias Current: 11nA; Input Offset Current(Ios): 5nA; Input Offset Voltage Drift(Vos TC): 9uV/℃; Input Voltage Noise Density: 46nV/√Hz@1kHz; Maximum Power Supply Range (Vdd-Vss): 5.5V; ModelId: 0a2a1b8f50944f73965918ad6e5fe167; ModelName: SOT-23-5_L2.9-W1.6-H1.1-LS2.8-P0.95; ModelTransform: 114.173,109.636,0,180,0,0,0,0,0; Number of Channels: 1; Output Current: 5mA; Quiescent Current: 170uA; Rail to Rail: 轨到轨输出; Single supply: 2.7V~5.5V; Slew Rate: 1V/us; Vos - Input Offset Voltage: 7mV; Component Kind: Standard; ConvertToPcb: yes; Datasheet: https://www.ti.com/cn/lit/gpn/lmv321; Dual Supply: -; Features: -; FootprintName: SOT-23-5_L3.0-W1.7-P0.95-LS2.8-BR; Input offset current drift(Ios TC): -; JLCPCB Part Class: Extended Part; LCSC Part Name: 低电压轨到轨输出运算放大器; ManufacturerPart: LMV321IDBVR; Operating Temperature: -40℃~+125℃; Supplier: LCSC; Supplier Footprint: SOT-23-5; SupplierPart: C7972; Symbol: LMV321IDBVR
U9 = ipex1-txz 142: 连接器类型:板端;接口类型:内针;端口数量:1;接口直径:2mm;接口长度/高度:1.25mm;频率:3GHz;射频系列:IPEX;阻抗:-;样式:-;工作温度:-40℃~+90℃;; Manufacturer: SHOU HAN(首韩); AddInBom: yes; Connector Type: 板端; Interface: 内针; Interface Diameter: 2mm; Interface Length/Height: 1.25mm; ModelId: 189d1a3756b042498321c30af9cbe72c; ModelName: ANT-SMD_3P-L3.0-W2.6; ModelTransform: 122.047,118.11,0,90,0,0,0.001,-29.842,0; Number of Ports: 1; Rated Voltage (Max): 60V; RF Series: IPEX; Value: 3GHz; Component Kind: Standard; ConvertToPcb: yes; Datasheet: https://item.szlcsc.com/datasheet/IPEX1-TXZ%2520142/3712563.html; FootprintName: ANT-SMD_3P-L3.0-W2.6; Impedance: -; JLCPCB Part Class: Extended Part; LCSC Part Name: 内针 IPEX; ManufacturerPart: IPEX1-TXZ 142; Operating Temperature Range: -40℃~+90℃; Style: -; Supplier: LCSC; Supplier Footprint: SMD; SupplierPart: C3151745; Symbol: IPEX1-TXZ 142
U10 = ml307c-dl: Manufacturer: Chinamobile(中移物联网); AddInBom: yes; ModelId: 2571ce606ab740e6abf98629ca0922ea; ModelTransform: 622.046,696.84892,0,0,0,0,0,0,0; Component Kind: Standard; ConvertToPcb: yes; Datasheet: https://item.szlcsc.com/datasheet/ML307C-DL/51301990.html; FootprintName: LCC-LGA-109_L17.7-W15.8-P1.10_MC661; JLCPCB Part Class: Extended Part; LCSC Part Name: ML307C-DL; ManufacturerPart: ML307C-DL; ModelName: LCC-LGA-109_L17.7-W15.8-P1.10_MC661; Supplier: LCSC; Supplier Footprint: SMD,17.7x15.8mm; SupplierPart: C48972417; Symbol: ML307C-DL
U11 = py32f071c1bu6tr: CPU内核:-;CPU最大主频:72MHz;; Manufacturer: PUYA(普冉); AddInBom: yes; CPU Maximum Speed: 72MHz; ModelId: 328ac7b89170445886be6763e9884c56; ModelName: VQFN-48_L6.0-W6.0-H0.9-P0.40-TL-EP; ModelTransform: 236.22,236.22,0,270,0,0,0,0,0; Program Memory Type: FLASH; Program Storage Size: 128KB; Component Kind: Standard; ConvertToPcb: yes; CPU Core: -; FootprintName: VQFN-48_L6.0-W6.0-P0.40-TL-EP4.4; JLCPCB Part Class: Extended Part; LCSC Part Name: M0内核 工业级MCU  128K Flash 16K RAM; ManufacturerPart: PY32F071C1BU6TR; Supplier: LCSC; Supplier Footprint: QFN48(6x6x0.75); SupplierPart: C7434410; Symbol: PY32F071C1BU6TR
LCD1 = LCD: Component Kind: Standard; Library Name: Schlib1.SchLib
U6 = TM1750: Component Kind: Standard; Library Name: Schlib1.SchLib
